# Altium schematic sheet TPM.SchDoc(TPM)
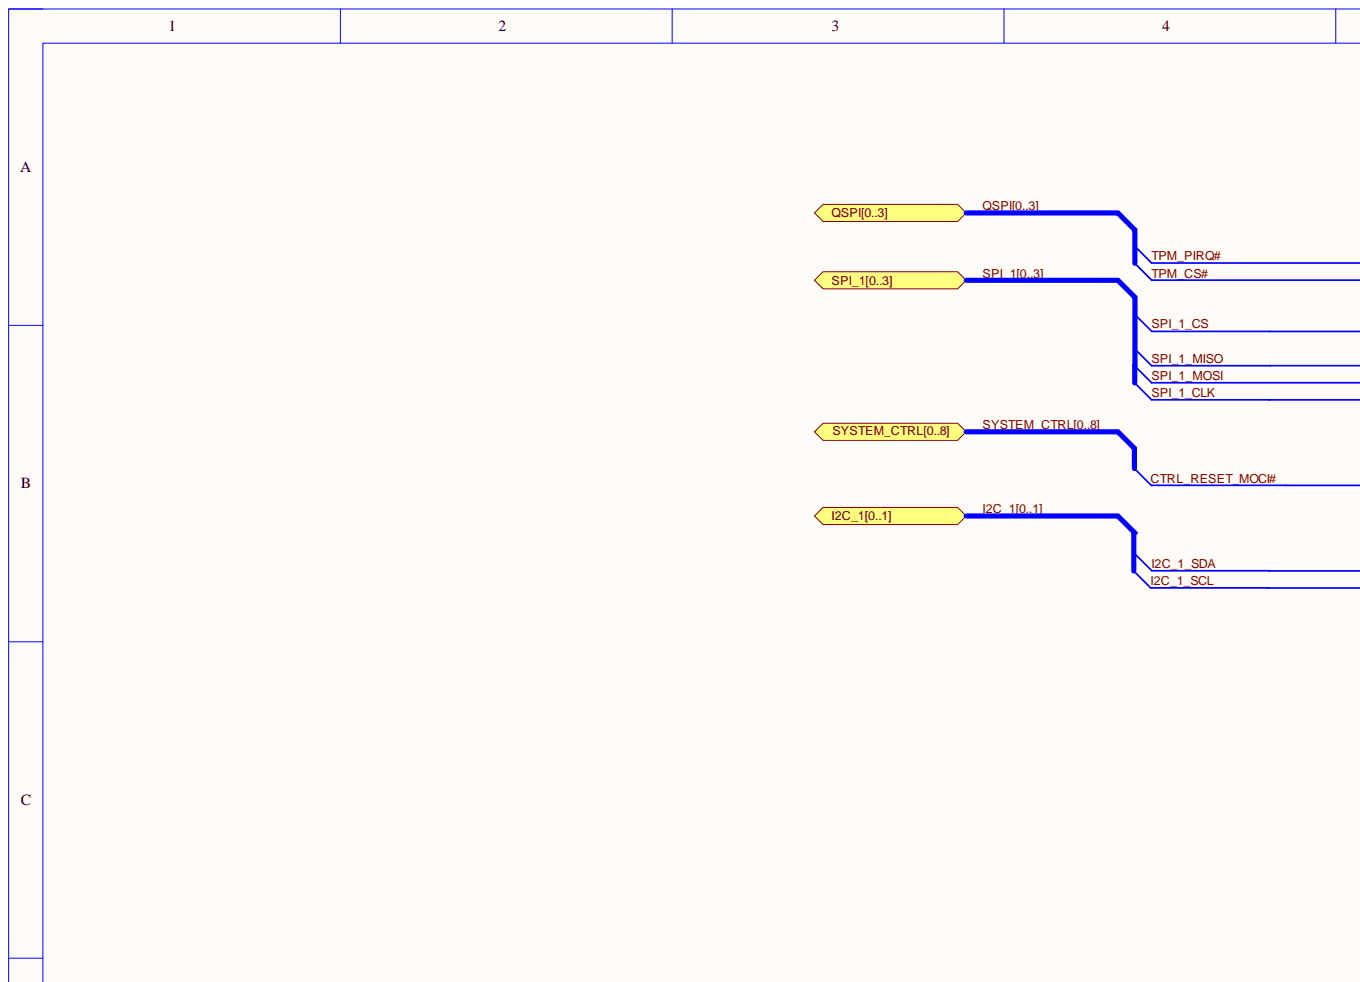
[diagram p0: TPM.SchDoc, top left]
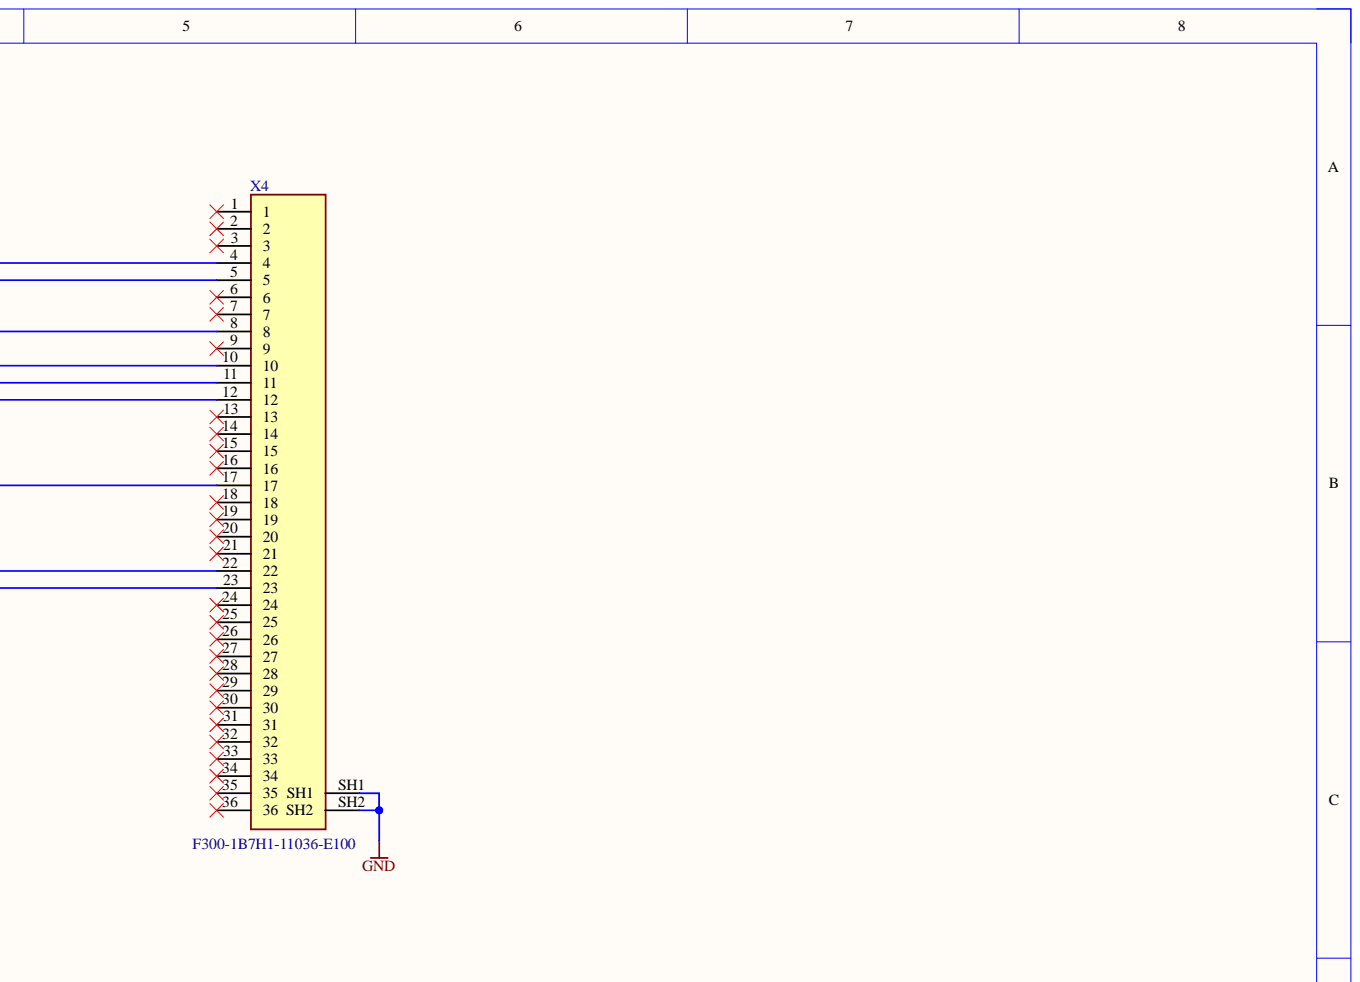
[diagram p1: TPM.SchDoc, top right]
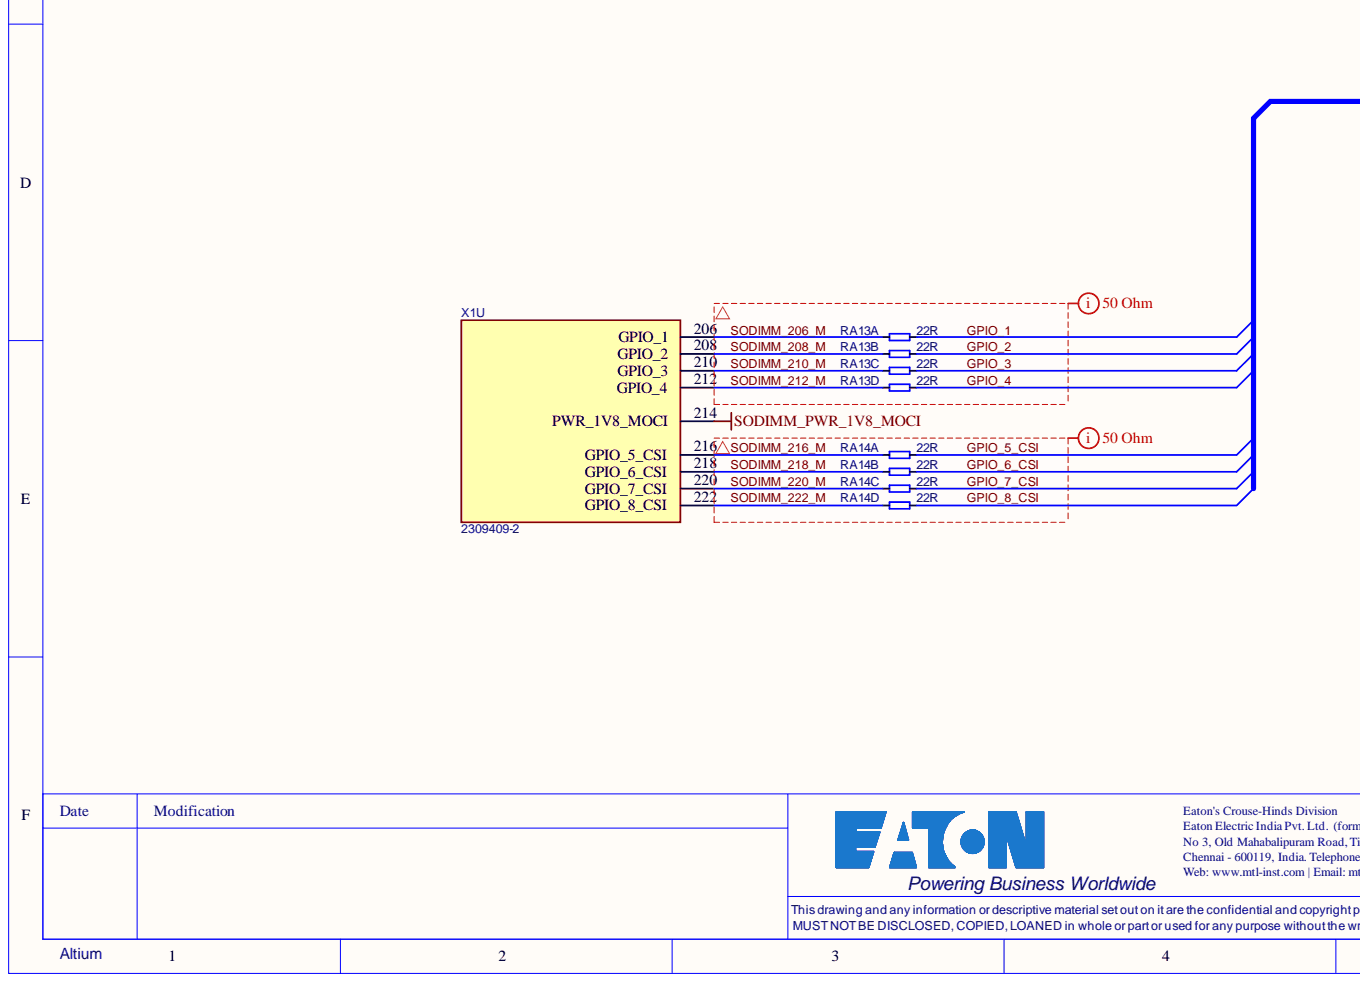
[diagram p2: TPM.SchDoc, bottom left]
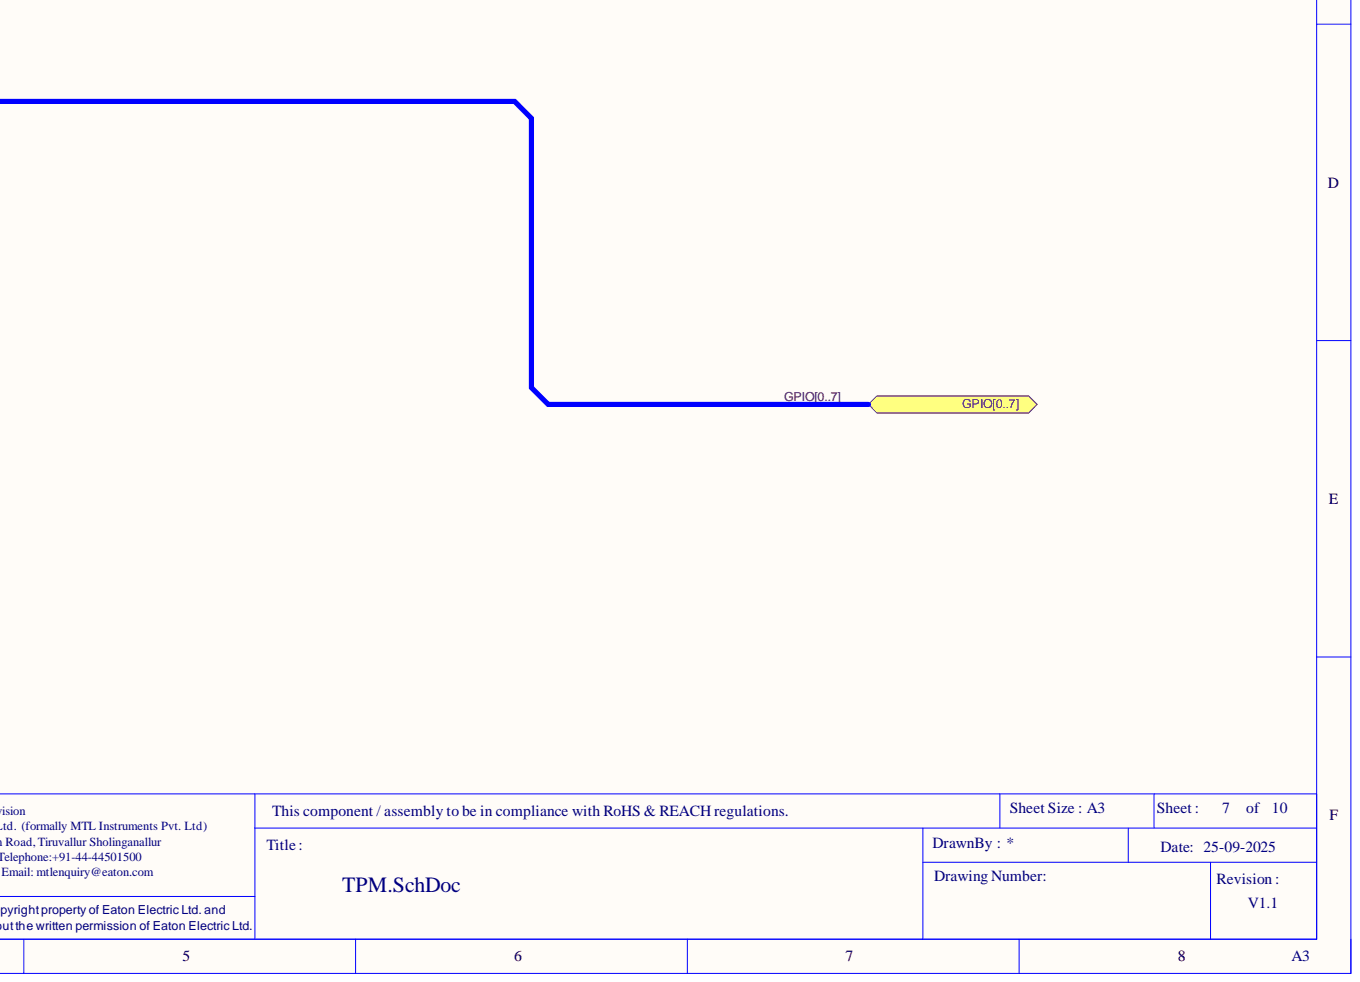
[diagram p3: TPM.SchDoc, bottom right]

## Parts
RA13  22R  [T_Resistor_Array_0402_X4]  (parts A,B,C,D)
RA14  22R  [T_Resistor_Array_0402_X4]  (parts A,B,C,D)
X1  2309409-2  [CON-TE-2309409-2]  (part U)
X4  CONN FFC BOTTOM 36POS 0.5MM R/A  [AMPHENOL_F300-1B7H1-11036-E100]  (value not drawn)

## Ports
GPIO0, GPIO1, GPIO2, GPIO3, GPIO4, GPIO5, GPIO6, GPIO7, GPIO[0..7], I2C_1[0..1], I2C_10, I2C_11, QSPI0, QSPI1, QSPI2, QSPI3, QSPI[0..3], SPI_1[0..3], SPI_10, SPI_11, SPI_12, SPI_13, SYSTEM_CTRL0, SYSTEM_CTRL1, SYSTEM_CTRL2, SYSTEM_CTRL3, SYSTEM_CTRL4, SYSTEM_CTRL5, SYSTEM_CTRL6, SYSTEM_CTRL7, SYSTEM_CTRL8, SYSTEM_CTRL[0..8]

## Nets
GND: X4.SH1 X4.SH2
SODIMM_PWR_1V8_MOCI: X1.214(PWR_1V8_MOCI)
CTRL_RESET_MOCI#: X4.17
GPIO_1: RA13.5
GPIO_2: RA13.6
GPIO_3: RA13.7
GPIO_4: RA13.8
GPIO_5_CSI: RA14.5
GPIO_6_CSI: RA14.6
GPIO_7_CSI: RA14.7
GPIO_8_CSI: RA14.8
I2C_1_SCL: X4.23
I2C_1_SDA: X4.22
SODIMM_206_M: RA13.1 X1.206(GPIO_1)
SODIMM_208_M: RA13.2 X1.208(GPIO_2)
SODIMM_210_M: RA13.3 X1.210(GPIO_3)
SODIMM_212_M: RA13.4 X1.212(GPIO_4)
SODIMM_216_M: RA14.1 X1.216(GPIO_5_CSI)
SODIMM_218_M: RA14.2 X1.218(GPIO_6_CSI)
SODIMM_220_M: RA14.3 X1.220(GPIO_7_CSI)
SODIMM_222_M: RA14.4 X1.222(GPIO_8_CSI)
SPI_1_CLK: X4.12
SPI_1_CS: X4.8
SPI_1_MISO: X4.10
SPI_1_MOSI: X4.11
TPM_CS#: X4.5
TPM_PIRQ#: X4.4
GPIO0: ; port GPIO[0..7]  [also labelled GPIO]
GPIO1: ; port GPIO[0..7]  [also labelled GPIO]
GPIO2: ; port GPIO[0..7]  [also labelled GPIO]
GPIO3: ; port GPIO[0..7]  [also labelled GPIO]
GPIO4: ; port GPIO[0..7]  [also labelled GPIO]
GPIO5: ; port GPIO[0..7]  [also labelled GPIO]
GPIO6: ; port GPIO[0..7]  [also labelled GPIO]
GPIO7: ; port GPIO[0..7]  [also labelled GPIO]
I2C_10: ; port I2C_1[0..1]  [also labelled I2C_1]
I2C_11: ; port I2C_1[0..1]  [also labelled I2C_1]
QSPI0: ; port QSPI[0..3]  [also labelled QSPI]
QSPI1: ; port QSPI[0..3]  [also labelled QSPI]
QSPI2: ; port QSPI[0..3]  [also labelled QSPI]
QSPI3: ; port QSPI[0..3]  [also labelled QSPI]
SPI_10: ; port SPI_1[0..3]  [also labelled SPI_1]
SPI_11: ; port SPI_1[0..3]  [also labelled SPI_1]
SPI_12: ; port SPI_1[0..3]  [also labelled SPI_1]
SPI_13: ; port SPI_1[0..3]  [also labelled SPI_1]
SYSTEM_CTRL0: ; port SYSTEM_CTRL[0..8]  [also labelled SYSTEM_CTRL]
SYSTEM_CTRL1: ; port SYSTEM_CTRL[0..8]  [also labelled SYSTEM_CTRL]
SYSTEM_CTRL2: ; port SYSTEM_CTRL[0..8]  [also labelled SYSTEM_CTRL]
SYSTEM_CTRL3: ; port SYSTEM_CTRL[0..8]  [also labelled SYSTEM_CTRL]
SYSTEM_CTRL4: ; port SYSTEM_CTRL[0..8]  [also labelled SYSTEM_CTRL]
SYSTEM_CTRL5: ; port SYSTEM_CTRL[0..8]  [also labelled SYSTEM_CTRL]
SYSTEM_CTRL6: ; port SYSTEM_CTRL[0..8]  [also labelled SYSTEM_CTRL]
SYSTEM_CTRL7: ; port SYSTEM_CTRL[0..8]  [also labelled SYSTEM_CTRL]
SYSTEM_CTRL8: ; port SYSTEM_CTRL[0..8]  [also labelled SYSTEM_CTRL]

Not connected: X4 1 2 3 6 7 9 13 14 15 16 18 19 20 21 24 25 26 27 28 29 30 31 32 33 34 35 36

## Library data of the parts
RA13 RA14 = RA-22R-63mW-5%-0804-div: Resistor Array 22 R 63mW 5% 0804; Component Kind: Standard; Power Dissipation: 63mW; Tolerance: 5%; Value: 22R; Library Name: Mallow.SchLib
X1 = CON-TE-2309409-2: DDR4 SODIMM Socket, 260 pins, 5.2mm(H), 0.5mm Pitch; Manufacturer Name: TE Connectivity; Component Kind: Standard; Manufacturer Part No.: 2309409-2; Package: DDR4-SODIMM-260; Temperature Range: -40C to +125C; Library Name: Mallow.SchLib
X4 = F300-1B7H1-11036-E100: 36 Position FFC, FPC Connector Contacts, Bottom 0.020" (0.50mm) Surface Mount, Right Angle; Manufacturer Name: Amphenol ICC / Aorora; Availability: Unavailable; Component Kind: Standard; Manufacturer Part No.: F300-1B7H1-11036-E100; Package: None; Price: None; Library Name: Mallow.SchLib
